# Altium schematic sheet openQCM_NEXT-MAIN.SchDoc
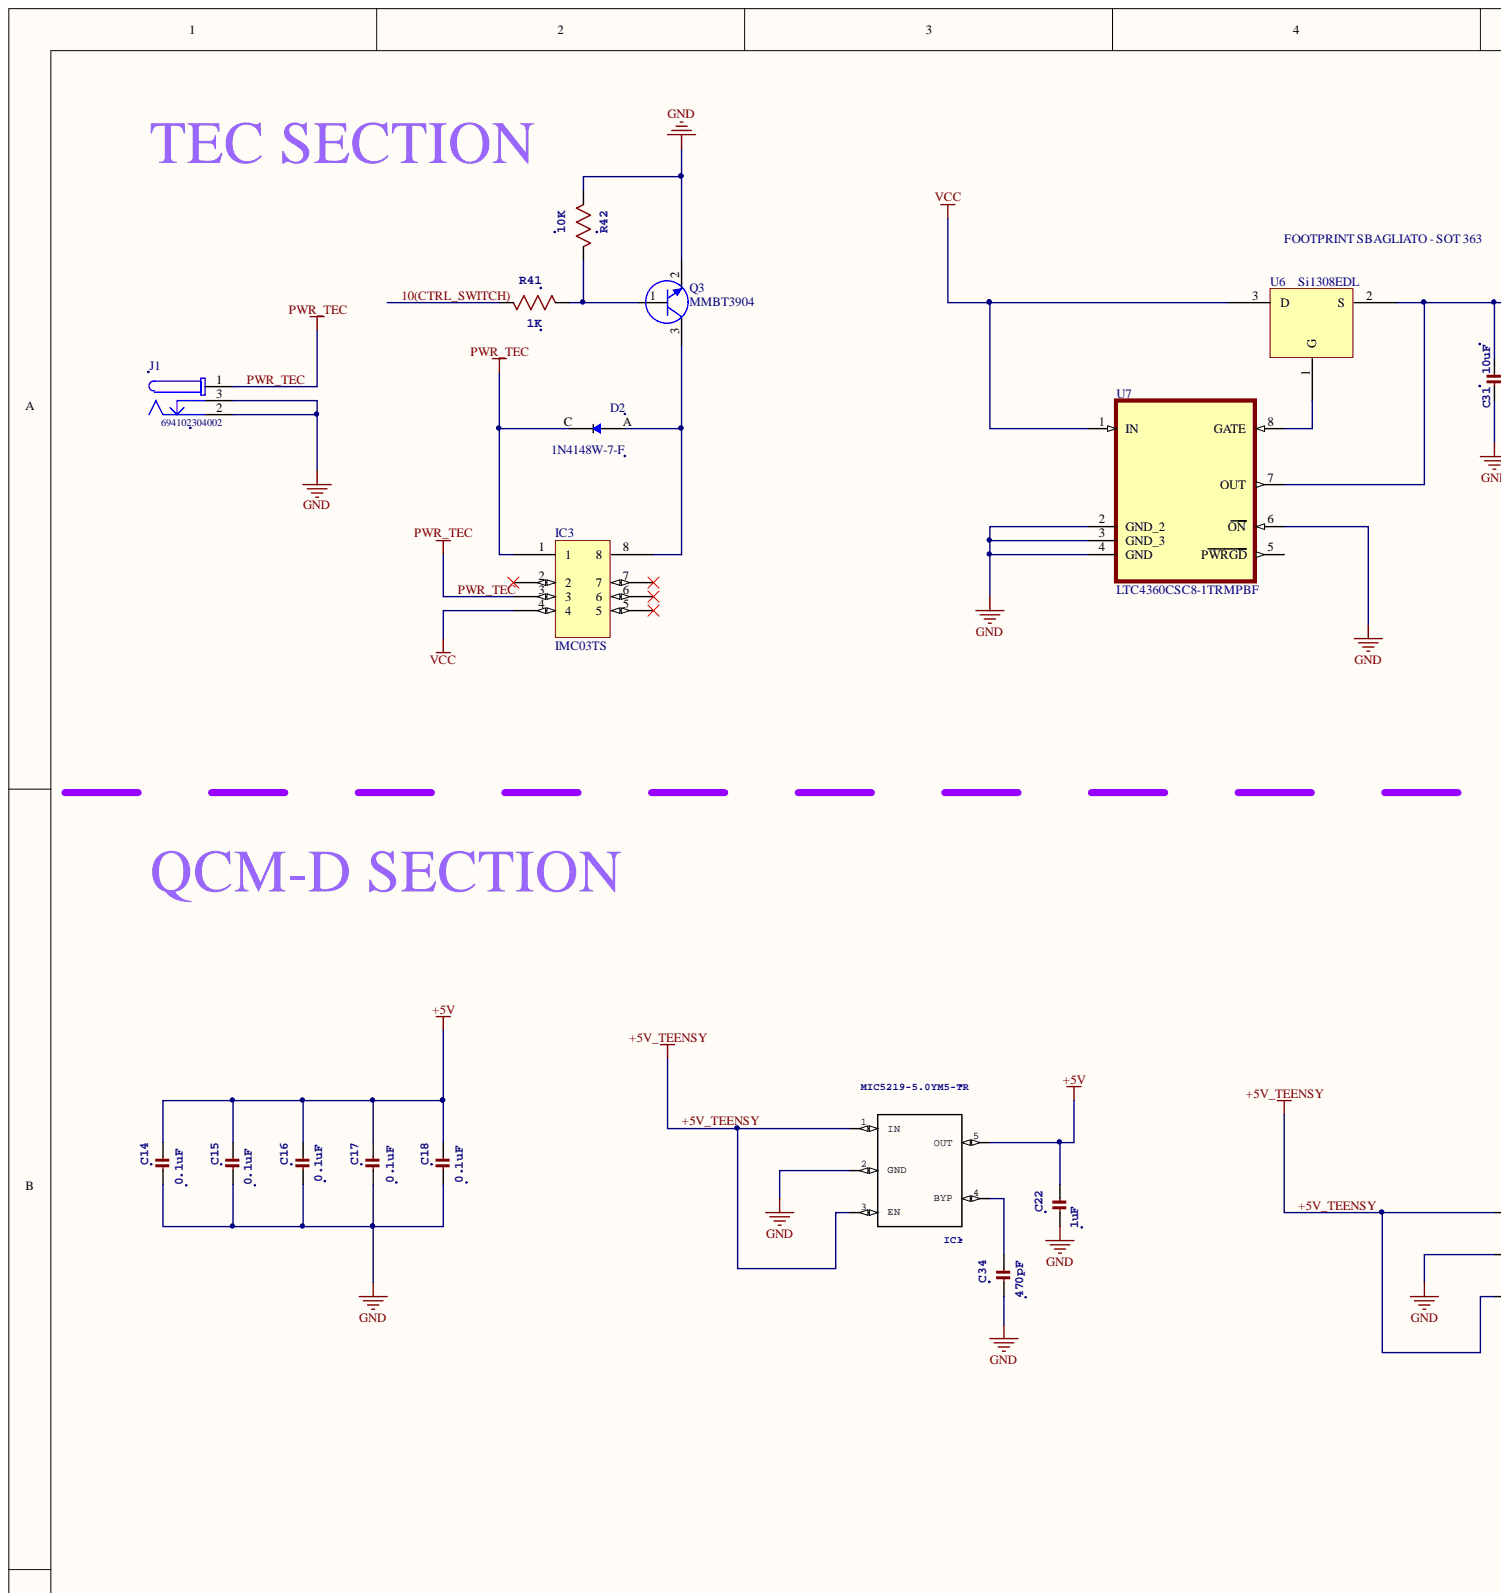
[diagram p0: openQCM_NEXT-MAIN.SchDoc, top left]
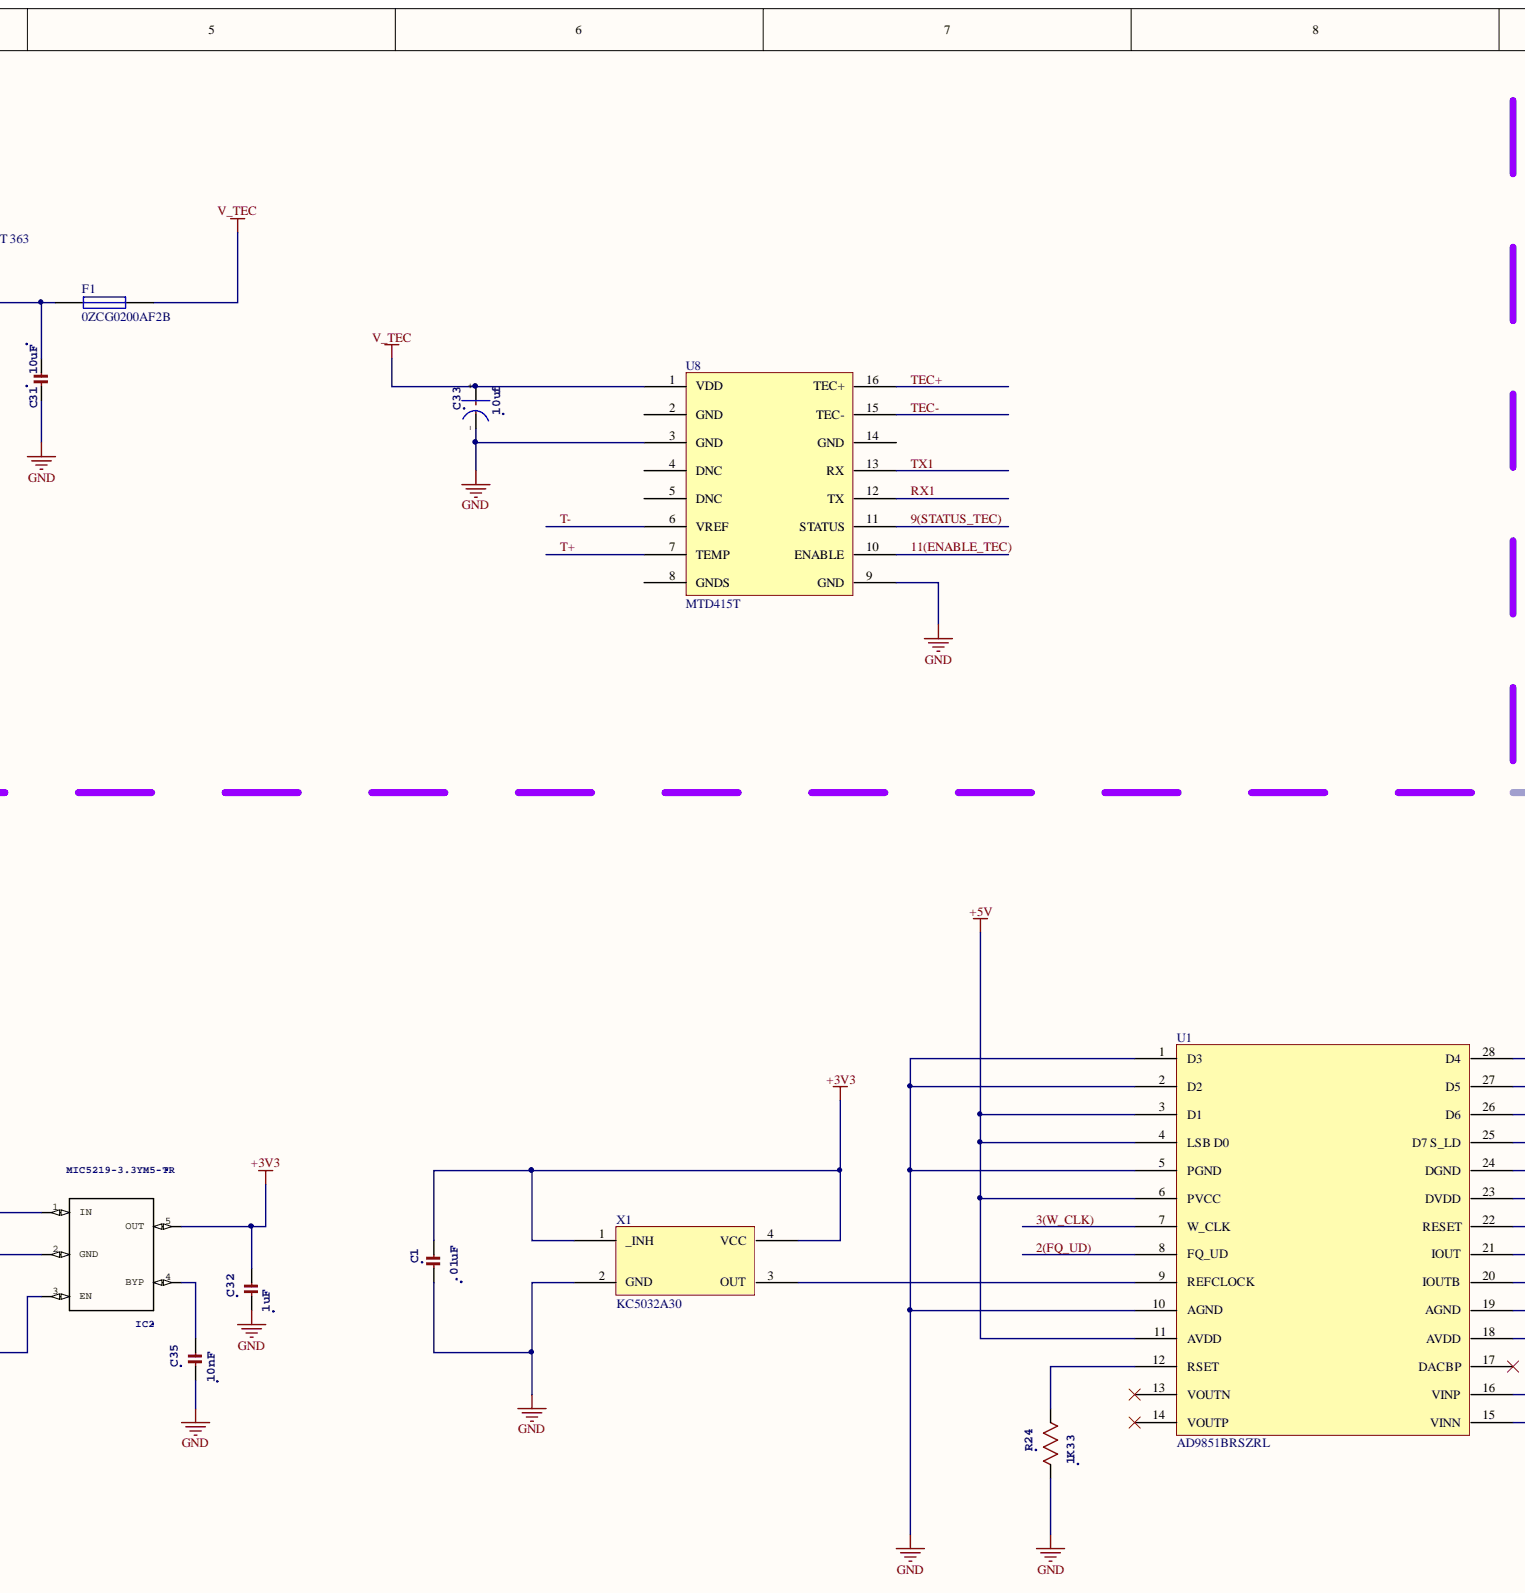
[diagram p1: openQCM_NEXT-MAIN.SchDoc, top centre]
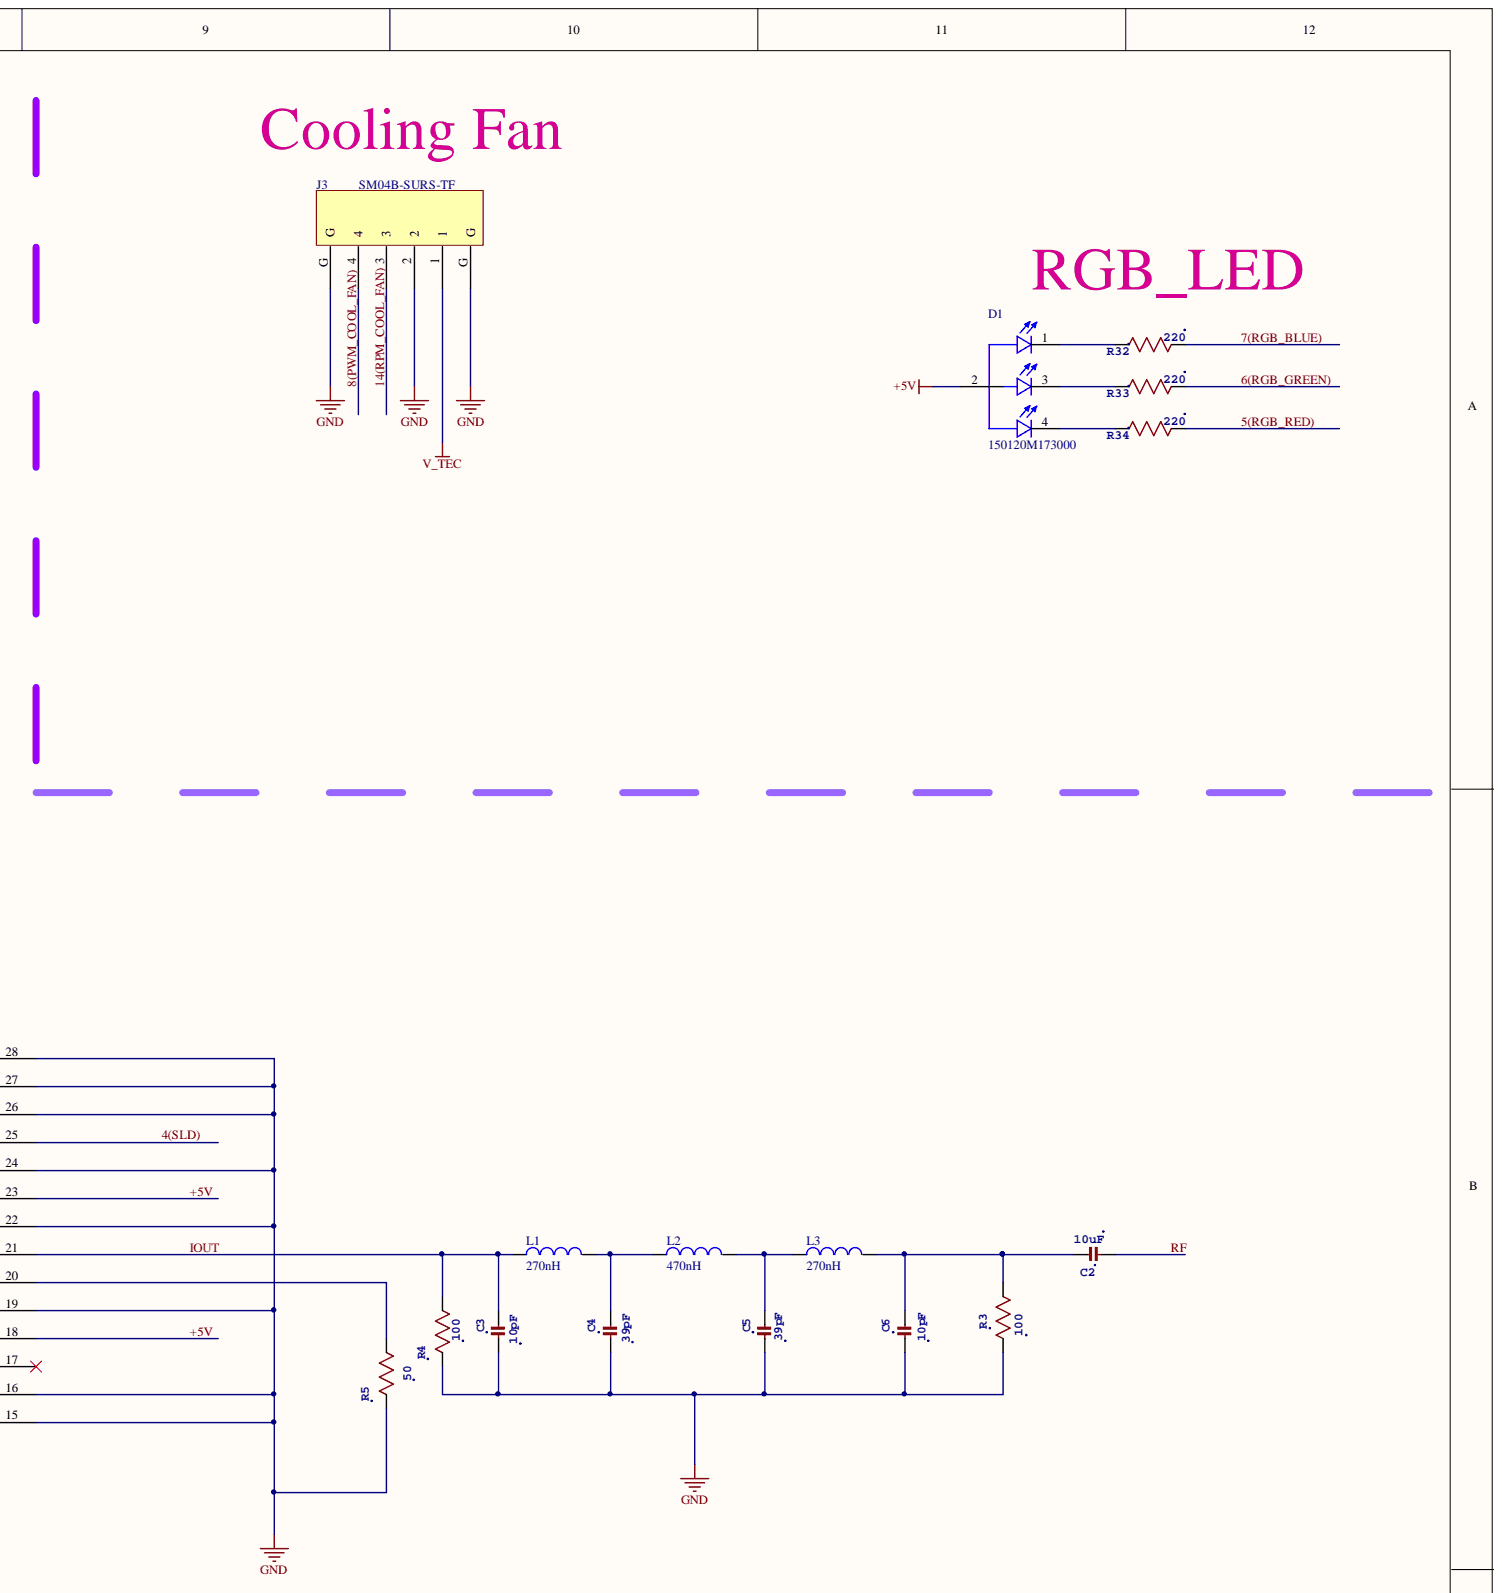
[diagram p2: openQCM_NEXT-MAIN.SchDoc, top right]
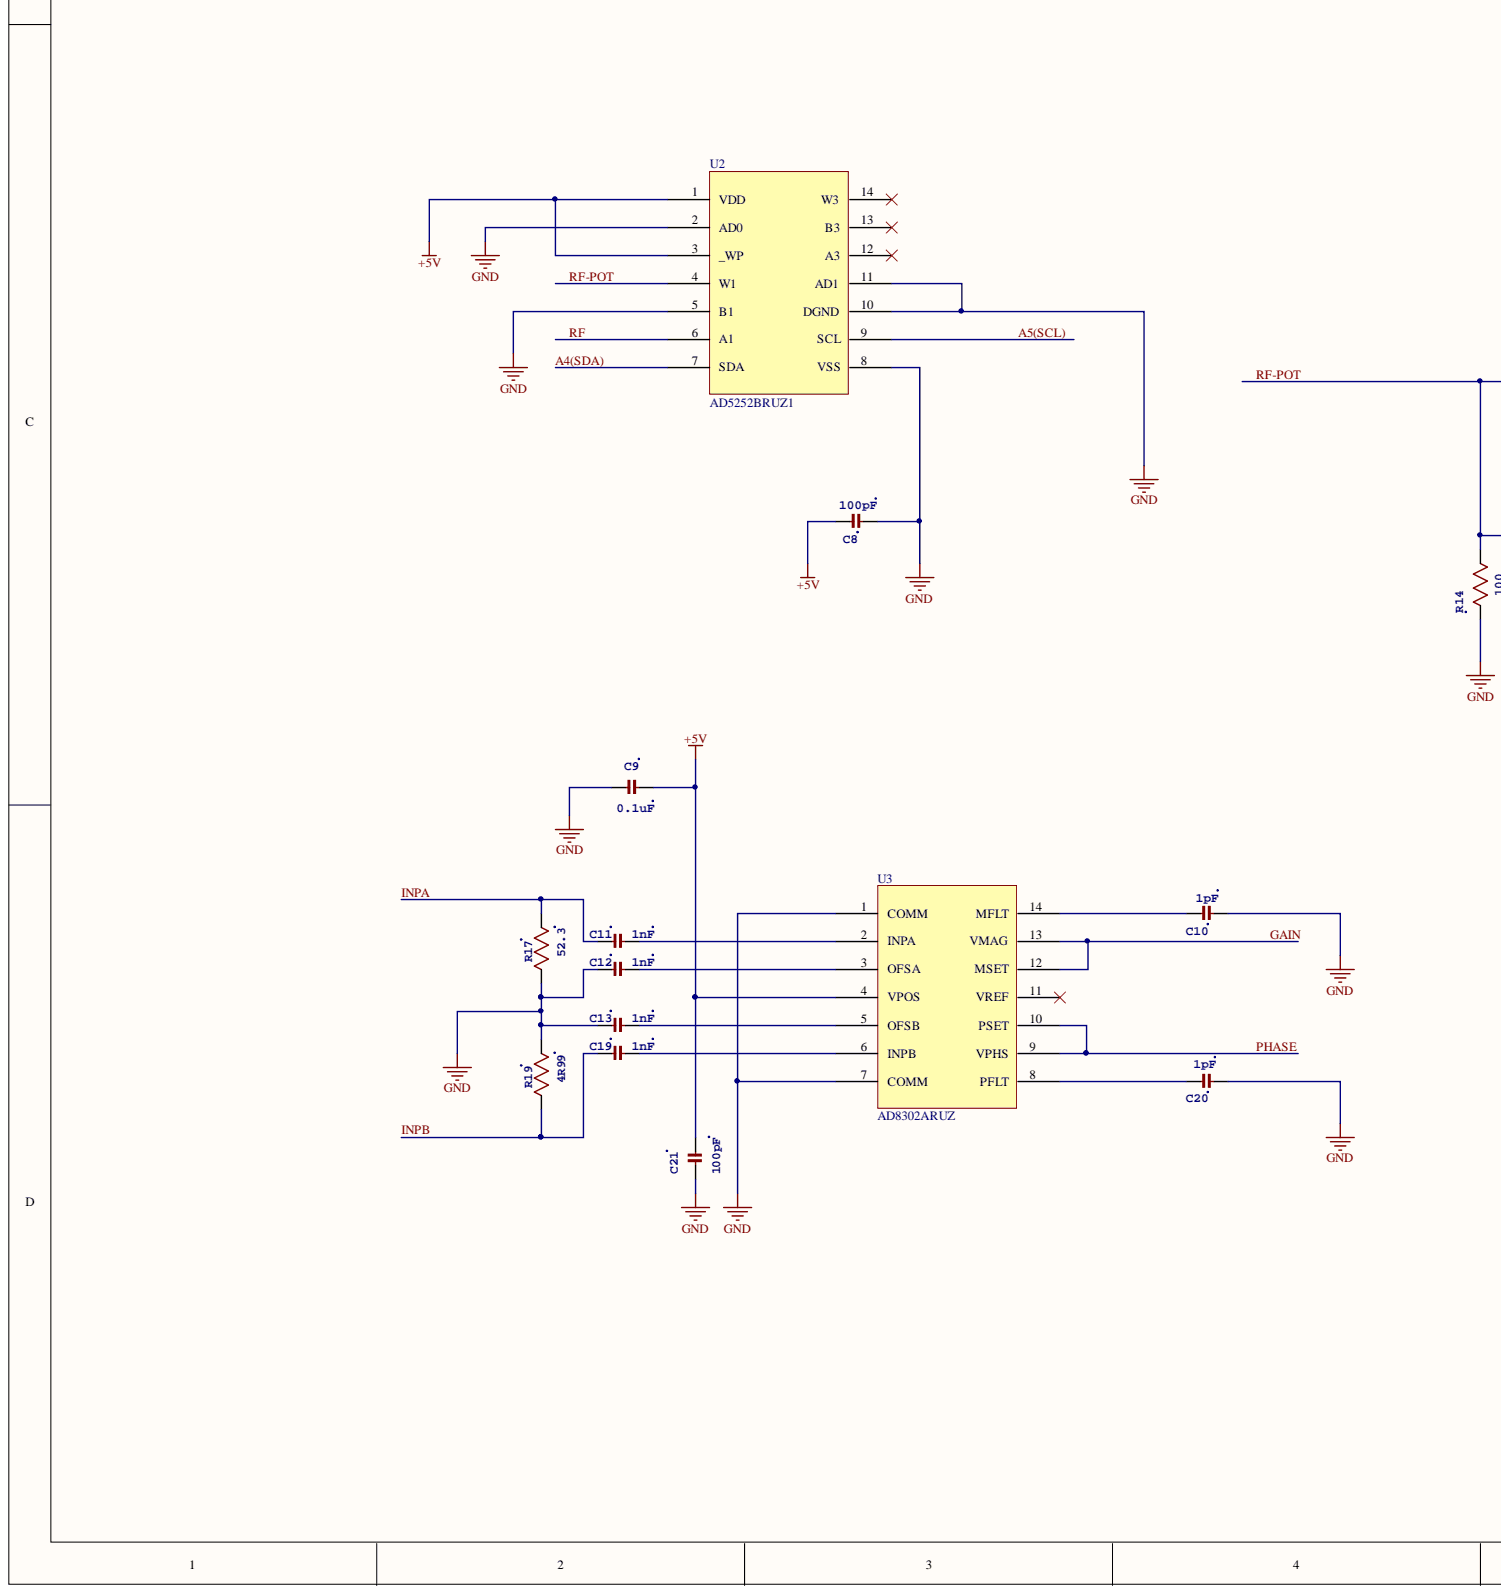
[diagram p3: openQCM_NEXT-MAIN.SchDoc, bottom left]
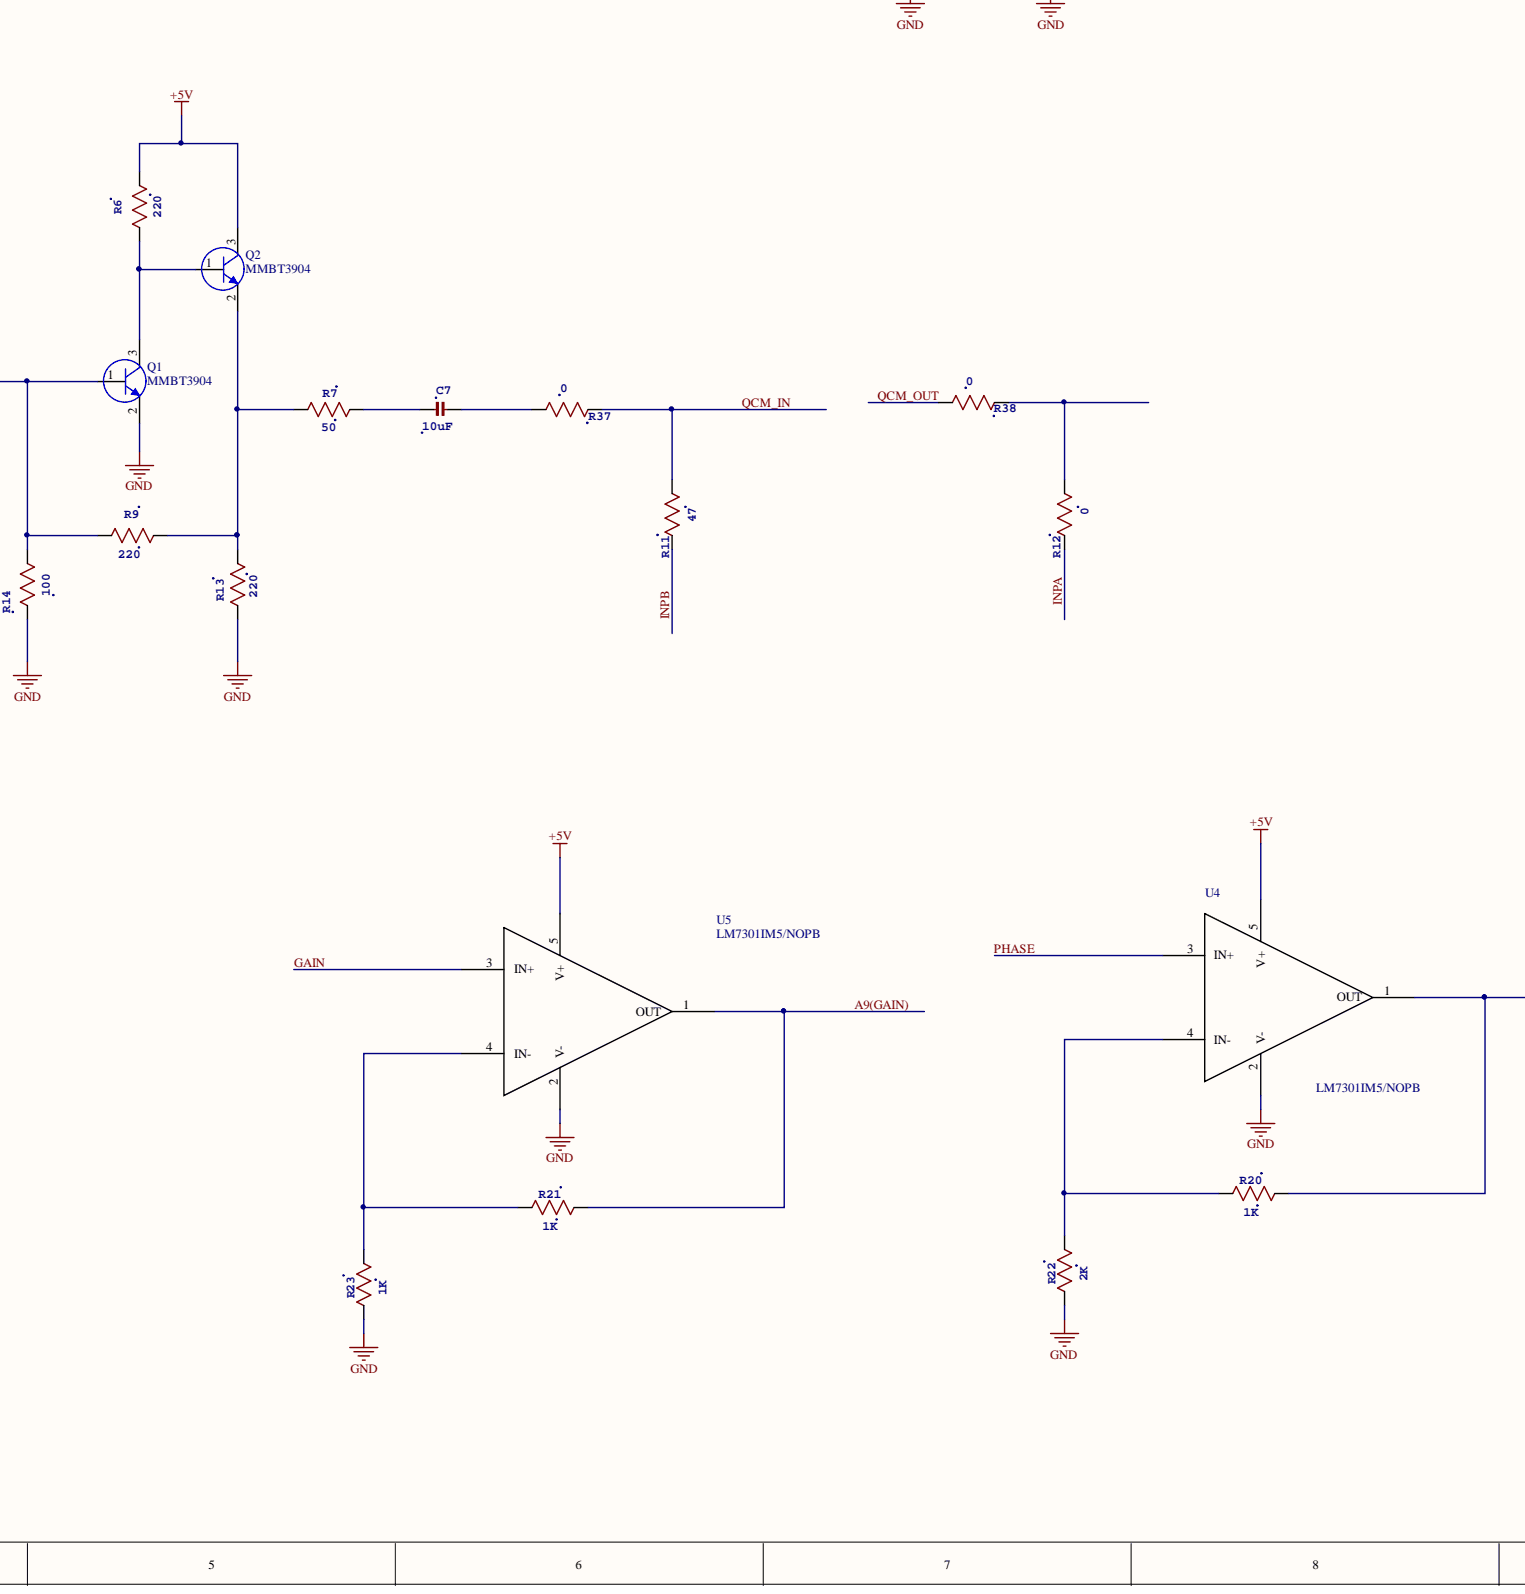
[diagram p4: openQCM_NEXT-MAIN.SchDoc, bottom centre]
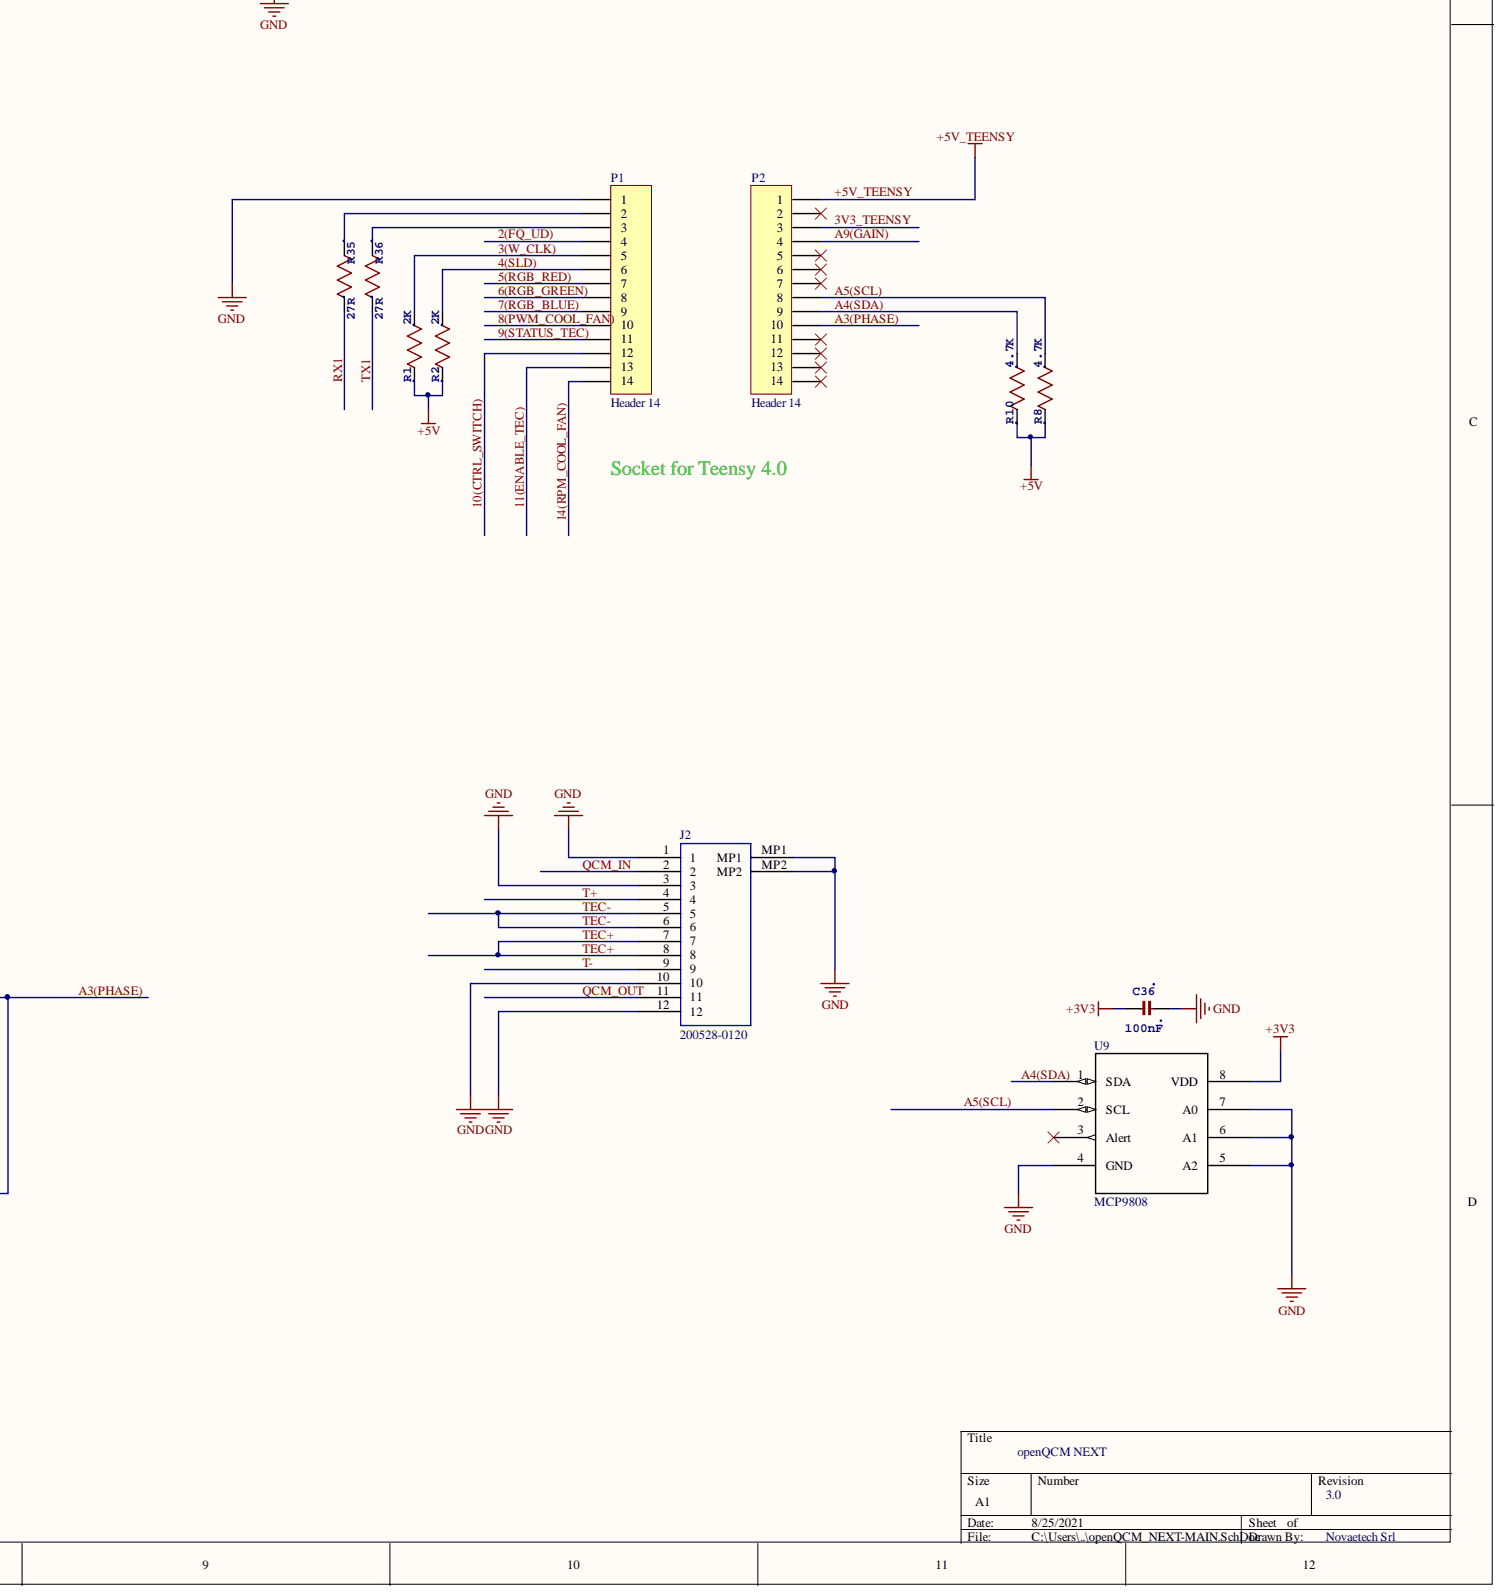
[diagram p5: openQCM_NEXT-MAIN.SchDoc, bottom right]

## Parts
C1  .01uF  [CAP-0805-NO]
C2  10uF  [CAP-0805-NO]
C3  10pF  [CAP-0805-NO]
C4  39pF  [CAP-0805-NO]
C5  39pF  [CAP-0805-NO]
C6  10pF  [CAP-0805-NO]
C7  10uF  [CAP-0805-NO]
C8  100pF  [CAP-0805-NO]
C9  0.1uF  [CAP-0805-NO]
C10  1pF  [CAP-0805-NO]
C11  1nF  [CAP-0805-NO]
C12  1nF  [CAP-0805-NO]
C13  1nF  [CAP-0805-NO]
C14  0.1uF  [CAP-0805-NO]
C15  0.1uF  [CAP-0805-NO]
C16  0.1uF  [CAP-0805-NO]
C17  0.1uF  [CAP-0805-NO]
C18  0.1uF  [CAP-0805-NO]
C19  1nF  [CAP-0805-NO]
C20  1pF  [CAP-0805-NO]
C21  100pF  [CAP-0805-NO]
C22  1uF  [CAP-0805-NO]
C31  10uF  [CAP-0805-NO]
C32  1uF  [CAP-0805-NO]
C33  10uf  [ECASD41E106M040KA0]
C34  470pF  [CAP-0805-NO]
C35  10nF  [CAP-0805-NO]
C36  100nF  [CAP-0805-NO]
D1  150120M173000  [150120M173000]
D2  1N4148W-7-F  [SOD-123]
F1  0ZCG0200AF2B  [Fuse_0ZCG0200AF2B]
IC1  MIC5219-5.0YM5-TR  [SOT-23-5]
IC2  MIC5219-3.3YM5-TR  [SOT-23-5]
IC3  IMC03TS  [1462037-4]
J1  694102304002  [694102304002]
J2  200528-0120  [2005280120]
J3  SM04B-SURS-TF  [SM04B-SURS-TF]
L1  270nH  [INductor 0805]
L2  470nH  [INductor 0805]
L3  270nH  [INductor 0805]
P1  Header 14  [HDR1X14]
P2  Header 14  [HDR1X14]
Q1  MMBT3904  [SOT95P240X120-3N]
Q2  MMBT3904  [SOT95P240X120-3N]
Q3  MMBT3904  [SOT95P240X120-3N]
R1  2K  [RESC2013X65N]
R2  2K  [RESC2013X65N]
R3  100  [RESC2013X65N]
R4  100  [RESC2013X65N]
R5  50  [RESC2013X65N]
R6  220  [RESC2013X65N]
R7  50  [RESC2013X65N]
R8  4.7K  [RESC2013X65N]
R9  220  [RESC2013X65N]
R10  4.7K  [RESC2013X65N]
R11  47  [RESC2013X65N]
R12  0  [RESC2013X65N]
R13  220  [RESC2013X65N]
R14  100  [RESC2013X65N]
R17  52.3  [RESC2013X65N]
R19  4R99  [RESC2013X65N]
R20  1K  [RESC2013X65N]
R21  1K  [RESC2013X65N]
R22  2K  [RESC2013X65N]
R23  1K  [RESC2013X65N]
R24  1K33  [RESC2013X65N]
R32  220  [RESC2013X65N]
R33  220  [RESC2013X65N]
R34  220  [RESC2013X65N]
R35  27R  [RESC2013X65N]
R36  27R  [RESC2013X65N]
R37  0  [RESC2013X65N]
R38  0  [RESC2013X65N]
R41  1K  [RESC2013X65N]
R42  10K  [RESC2013X65N]
U1  AD9851BRSZRL  [SOP65P780X200-28N]
U2  AD5252BRUZ1  [TSSOP-14]
U3  AD8302ARUZ  [TSSOP-14]
U4  LM7301IM5/NOPB  [SOT-23-5]
U5  LM7301IM5/NOPB  [SOT-23-5]
U6  Si1308EDL  [SOT323]
U7  LTC4360CSC8-1TRMPBF  [SOT50P210X100-8N]
U8  MTD415T  [MTD415T]
U9  MCP9808  [DFN-8]
X1  KC5032A30  [KC5032A30]

## Nets
+3V3: C1.1 C32.1 C36.1 IC2.5(OUT) U9.8(VDD) X1.1(_INH) X1.4(VCC)
GND: C1.2 C3.2 C4.2 C5.2 C6.2 C8.2 C9.1 C10.2 C12.1 C13.1 C14.2 C15.2 C16.2 C17.2 C18.2 C20.2 C21.1 C22.2 C31.1 C32.2 C33.- C34.2 C35.2 C36.2 IC1.2(GND) IC2.2(GND) J1.2 J1.3 J2.1 J2.3 J2.10 J2.12 J2.MP1 J2.MP2 J3.2 J3.G P1.1 Q1.2 Q3.2 R3.2 R4.2 R5.2 R13.1 R14.2 R17.1 R19.2 R22.1 R23.1 R24.2 R42.1 U1.1(D3) U1.2(D2) U1.5(PGND) U1.10(AGND) U1.15(VINN) U1.16(VINP) U1.19(AGND) U1.22(RESET) U1.24(DGND) U1.26(D6) U1.27(D5) U1.28(D4) U2.2(AD0) U2.5(B1) U2.8(VSS) U2.10(DGND) U2.11(AD1) U3.1(COMM) U3.7(COMM) U4.2(V-) U5.2(V-) U7.2(GND_2) U7.3(GND_3) U7.4(GND) U7.6(ON) U8.3(GND) U8.9(GND) U9.4(GND) U9.5(A2) U9.6(A1) U9.7(A0) X1.2(GND)
V_TEC: C33.+ F1.2 J3.1 U8.1(VDD)
VCC: IC3.4 U6.3 U7.1(IN)
2(FQ_UD): P1.4 U1.8(FQ_UD)
3(W_CLK): P1.5 R1.1 U1.7(W_CLK)
3V3_TEENSY: P2.3
4(SLD): P1.6 R2.1 U1.25(D7_S_LD)
5(RGB_RED): P1.7 R34.2
6(RGB_GREEN): P1.8 R33.2
7(RGB_BLUE): P1.9 R32.2
8(PWM_COOL_FAN): J3.4 P1.10
9(STATUS_TEC): P1.11 U8.11(STATUS)
10(CTRL_SWITCH): P1.12 R41.1
11(ENABLE_TEC): P1.13 U8.10(ENABLE)
14(RPM_COOL_FAN): J3.3 P1.14
+5V: C8.1 C9.2 C14.1 C15.1 C16.1 C17.1 C18.1 C21.2 C22.1 D1.2 IC1.5(OUT) Q2.3 R1.2 R2.2 R6.2 R8.2 R10.2 U1.3(D1) U1.4(LSB_D0) U1.6(PVCC) U1.11(AVDD) U1.18(AVDD) U1.23(DVDD) U2.1(VDD) U2.3(_WP) U3.4(VPOS) U4.5(V+) U5.5(V+)
+5V_TEENSY: IC1.1(IN) IC1.3(EN) IC2.1(IN) IC2.3(EN) P2.1
A3(PHASE): P2.10 R20.2 U4.1(OUT)
A4(SDA): P2.9 R10.1 U2.7(SDA) U9.1(SDA)
A5(SCL): P2.8 R8.1 U2.9(SCL) U9.2(SCL)
A9(GAIN): P2.4 R21.2 U5.1(OUT)
GAIN: U3.12(MSET) U3.13(VMAG) U5.3(IN+)
INPA: C11.1 R12.1 R17.2
INPB: C19.1 R11.1 R19.1
IOUT: C3.1 L1.1 R4.1 U1.21(IOUT)
PHASE: U3.9(VPHS) U3.10(PSET) U4.3(IN+)
PWR_TEC: D2.C IC3.1 IC3.3 J1.1
QCM_IN: J2.2 R11.2 R37.1
QCM_OUT: J2.11 R38.2
RF: C2.2 U2.6(A1)
RF-POT: Q1.1 R9.1 R14.1 U2.4(W1)
RX1: R35.1 U8.12(TX)
T+: J2.4 U8.7(TEMP)
T-: J2.9 U8.6(VREF)
TEC+: J2.7 J2.8 U8.16(TEC+)
TEC-: J2.5 J2.6 U8.15(TEC-)
TX1: R36.1 U8.13(RX)
NetC2_1: C2.1 C6.1 L3.2 R3.1
NetC4_1: C4.1 L1.2 L2.1
NetC5_1: C5.1 L2.2 L3.1
NetC7_1: C7.1 R37.2
NetC7_2: C7.2 R7.2
NetC10_1: C10.1 U3.14(MFLT)
NetC11_2: C11.2 U3.2(INPA)
NetC12_2: C12.2 U3.3(OFSA)
NetC13_2: C13.2 U3.5(OFSB)
NetC19_2: C19.2 U3.6(INPB)
NetC20_1: C20.1 U3.8(PFLT)
NetC31_2: C31.2 F1.1 U6.2 U7.7(OUT)
NetC34_1: C34.1 IC1.4(BYP)
NetC35_1: C35.1 IC2.4(BYP)
NetD1_1: D1.1 R32.1
NetD1_3: D1.3 R33.1
NetD1_4: D1.4 R34.1
NetD2_A: D2.A IC3.8 Q3.3
NetP1_2: P1.2 R35.2
NetP1_3: P1.3 R36.2
NetQ1_3: Q1.3 Q2.1 R6.1
NetQ2_2: Q2.2 R7.1 R9.2 R13.2
NetQ3_1: Q3.1 R41.2 R42.2
NetR5_1: R5.1 U1.20(IOUTB)
NetR12_2: R12.2 R38.1
NetR20_1: R20.1 R22.2 U4.4(IN-)
NetR21_1: R21.1 R23.2 U5.4(IN-)
NetR24_1: R24.1 U1.12(RSET)
NetU1_9: U1.9(REFCLOCK) X1.3(OUT)
NetU6_1: U6.1 U7.8(GATE)

Not connected: IC3 2 5 6 7; P2 2 5 6 7 11 12 13 14; U1 13(VOUTN) 14(VOUTP) 17(DACBP); U2 12(A3) 13(B3) 14(W3); U3 11(VREF); U7 5(PWRGD); U8 2(GND) 4(DNC) 5(DNC) 8(GNDS) 14(GND); U9 3(Alert)

## Library data of the parts
C1-C22 C31 C32 C34-C36 = CAPACITOR 0805: Component Kind: Standard; Library Name: openQCM_Q-1SchLib.SchLib
C33 = ECASD41E106M040KA0: Component Kind: Standard; Library Name: openQCM_SchLib.SchLib
D1 = 150120M173000: Red, Green, Blue _RGB_ LED Indication - Discrete 1.8V Red, 3V Green, 3V Blue 4-DIP _0.200, 5.08mm_; MF: Adafruit Industries; Availability: Unavailable; Component Kind: Standard; MP: 150120M173000; Package: DIP-4 Adafruit Industries; Price: None; SnapEDA_Link: https://www.snapeda.com/parts/1451/Adafruit%20Industries%20LLC/view-part/769402/?ref=snap; Library Name: openQCM_SchLib.SchLib
D2 = 1N4148W-7-F: DIODE, ULTRAFAST RECOVERY, 300mA, 75V, SOD-123-2, FULL REEL; MF: Multicomp; Availability: Unavailable; Component Kind: Standard; MP: 1N4148W-7-F; Package: SOD-123 Multicomp; Price: None; SnapEDA_Link: https://www.snapeda.com/parts/1N4148W-7-F/Multicomp/view-part/?ref=snap; Library Name: openQCM_SchLib.SchLib
F1 = Fuse 1: Fuse; Component Kind: Standard; LatestRevisionDate: 17-Jul-2002; LatestRevisionNote: Re-released for DXP Platform.; Library Name: Miscellaneous Devices.IntLib; PackageDescription: Thru-Hole; 2 Leads; PackageReference: PIN-W2/E2.8; Published: 8-Jun-2000; Publisher: Altium Limited
IC1 IC2 = MIC5219-5.0YM5-TR: Manufacturer_Name: Texas Instruments; Manufacturer_Part_Number: LMR62421XMF/NOPB; GateName_1: G$1; Component Kind: Standard; Description: MIC5219-5.0YM5-TR; DeviceSetName: MIC5219-5.0YM5-TR; Height: 1.45mm; LibraryName: MIC5219-5.0YM5-TR; Mouser_Part_Number: MIC5219-5.0YM5-TR; Mouser_Price-Stock: https://www.mouser.com/Search/Refine.aspx?Keyword=926-LMR62421XMF%2FNOPB; SymbolName_1: MIC5219-5.0YM5-TR; Library Name: openQCM_Q-1SchLib.SchLib
IC3 = 1462037-4: Component Kind: Standard; Library Name: openQCM_SchLib.SchLib
J1 = 694102304002: DC Power Jack Connector Low Profile WR-DC, Male, Right Angled, THT, 2 A, 20 V; Opening Diameter: 3.8 mm; Plug Inner Diameter: 1.05 mm; Plug Outer Diameter: 3.5 mm; Rated Current: 2 A; Working Voltage: 20 V; Component Kind: Standard; ComponentLink1Description: Manufacturer; ComponentLink1URL: http://www.we-online.de; ComponentLink2Description: Datasheet; ComponentLink2URL: http://katalog.we-online.de/em/datasheet/6941xx304002.pdf; Gender: Male; Mounting Technology: THT; Operating Temperature: -40 to 85 degC; Orientation: Right Angled; Packaging: Tray; Part Number: 694102304002; Published Date: August 2018; Library Name: openQCM_SchLib.SchLib; Publisher: Wurth Elektronik
J2 = 200528-0120: FFC & FPC Connectors 1.0 FPC ZIF DBL BTM CONT 6CKT RA FD19; MF: Molex; Availability: Warning; Check_prices: https://www.snapeda.com/parts/200528-0060/Molex/view-part/3618814/?ref=eda; Component Kind: Standard; MP: 200528-0120; Package: None; Price: None; Purchase-URL: https://pricing.snapeda.com/search/part/200528-0060/?ref=eda; SnapEDA_Link: https://www.snapeda.com/parts/200528-0060/Molex/view-part/3618814/?ref=snap; Library Name: openQCM_SchLib.SchLib
L1-L3 = Inductor: Inductor 0805; Code_IEC: 1005; Code_JEITA: 0402; Component Kind: Standard; LatestRevisionDate: 29-May-2009; LatestRevisionNote: IPC-7351 Footprint Added.; PackageDescription: Chip Inductor; PackageReference: 0402-A; Published: 8-Jun-2000; Library Name: openQCM_Q-1SchLib.SchLib; Publisher: Altium Limited
  L1 L3: Value: 270nH
  L2: Value: 470nH
P1 P2 = Header 14: Header, 14-Pin; Component Kind: Standard; LatestRevisionDate: 17-Jul-2002; LatestRevisionNote: Re-released for DXP Platform.; Library Name: Miscellaneous Connectors.IntLib; Publisher: Altium Limited
Q1-Q3 = MMBT3904: NPN General Purpose Amplifier; Component Kind: Standard; ComponentLink1Description: Manufacturer Link; ComponentLink1URL: http://www.fairchildsemi.com/; ComponentLink2Description: Datasheet; ComponentLink2URL: http://www.fairchildsemi.com/ds/2N%2F2N3904.pdf; DatasheetVersion: 1997; LatestRevisionDate: 15-Jan-2003; LatestRevisionNote: PCB Footprint 'BCY-W3/D4.7' replaced; PackageDescription: TO, Flat Index; 3 In-Line, Axial Leads; Body Dia. 4.5mm; Leads 0.51 x 0.48 mm (max); PackageReference: SOT-23-3; PackageVersion: Sep-1998; Published: 8-Jun-2000; Library Name: openQCM_Q-1SchLib.SchLib; Publisher: Altium Limited
R1-R14 R17 R19-R24 R32-R38 R41 R42 = RT0805FRE072K7L: MF: Yageo; Availability: Good; Component Kind: Standard; MP: RT0805FRE072K7L; Package: 0805 Yageo; Price: 0.03 USD; Library Name: openQCM_Q-1SchLib.SchLib
U6 = PMV15ENEAR: Component Kind: Standard; Library Name: openQCM_SchLib.SchLib
U7 = LTC4360CSC8-1TRMPBF: Over Voltage Protection Controller Automotive 8-Pin SC-70 T/R; MF: Analog Devices; MP: LTC4360CSC8-1#TRMPBF; Availability: Warning; Component Kind: Standard; DigiKey-Purchase-URL: https://snapeda.com/shop?store=DigiKey&id=4347983; Mouser-Purchase-URL: https://snapeda.com/shop?store=Mouser&id=4347983; Package: SC70-8 Analog Devices; Price: None; SnapEDA_Link: https://www.snapeda.com/parts/LTC4360CSC8-1%23TRMPBF/Analog%20Devices/view-part/4347983/?ref=snap; Library Name: openQCM_Q-1SchLib.SchLib
U8 = MTD415T: Manufacturer: Thorlabs GmbH; Component Kind: Standard; Description: MTD415T; Library Name: openQCM_Q-1SchLib.SchLib
J3 = SM04B-SURS-TF: Component Kind: Standard; Library Name: openQCM_SchLib.SchLib
U1 = AD9851BRSZRL: Component Kind: Standard; Library Name: openQCM_Q-1SchLib.SchLib
U2 = AD5252BRUZ1: Component Kind: Standard; Library Name: openQCM_Q-1SchLib.SchLib
U3 = AD8302ARUZ: Component Kind: Standard; Library Name: openQCM_Q-1SchLib.SchLib
U4 U5 = LM7301IM5/NOPB: Component Kind: Standard
  U4: Library Name: openQCM_Q-1SchLib.SchLib
  U5: Library Name: openQCM_SchLib.SchLib
U9 = MCP9808: Component Kind: Standard; Library Name: openQCM_Q-1SchLib.SchLib
X1 = KC5032A30: Component Kind: Standard; Library Name: openQCM_Q-1SchLib.SchLib
